# Altium schematic sheet Shift Registers.SchDoc
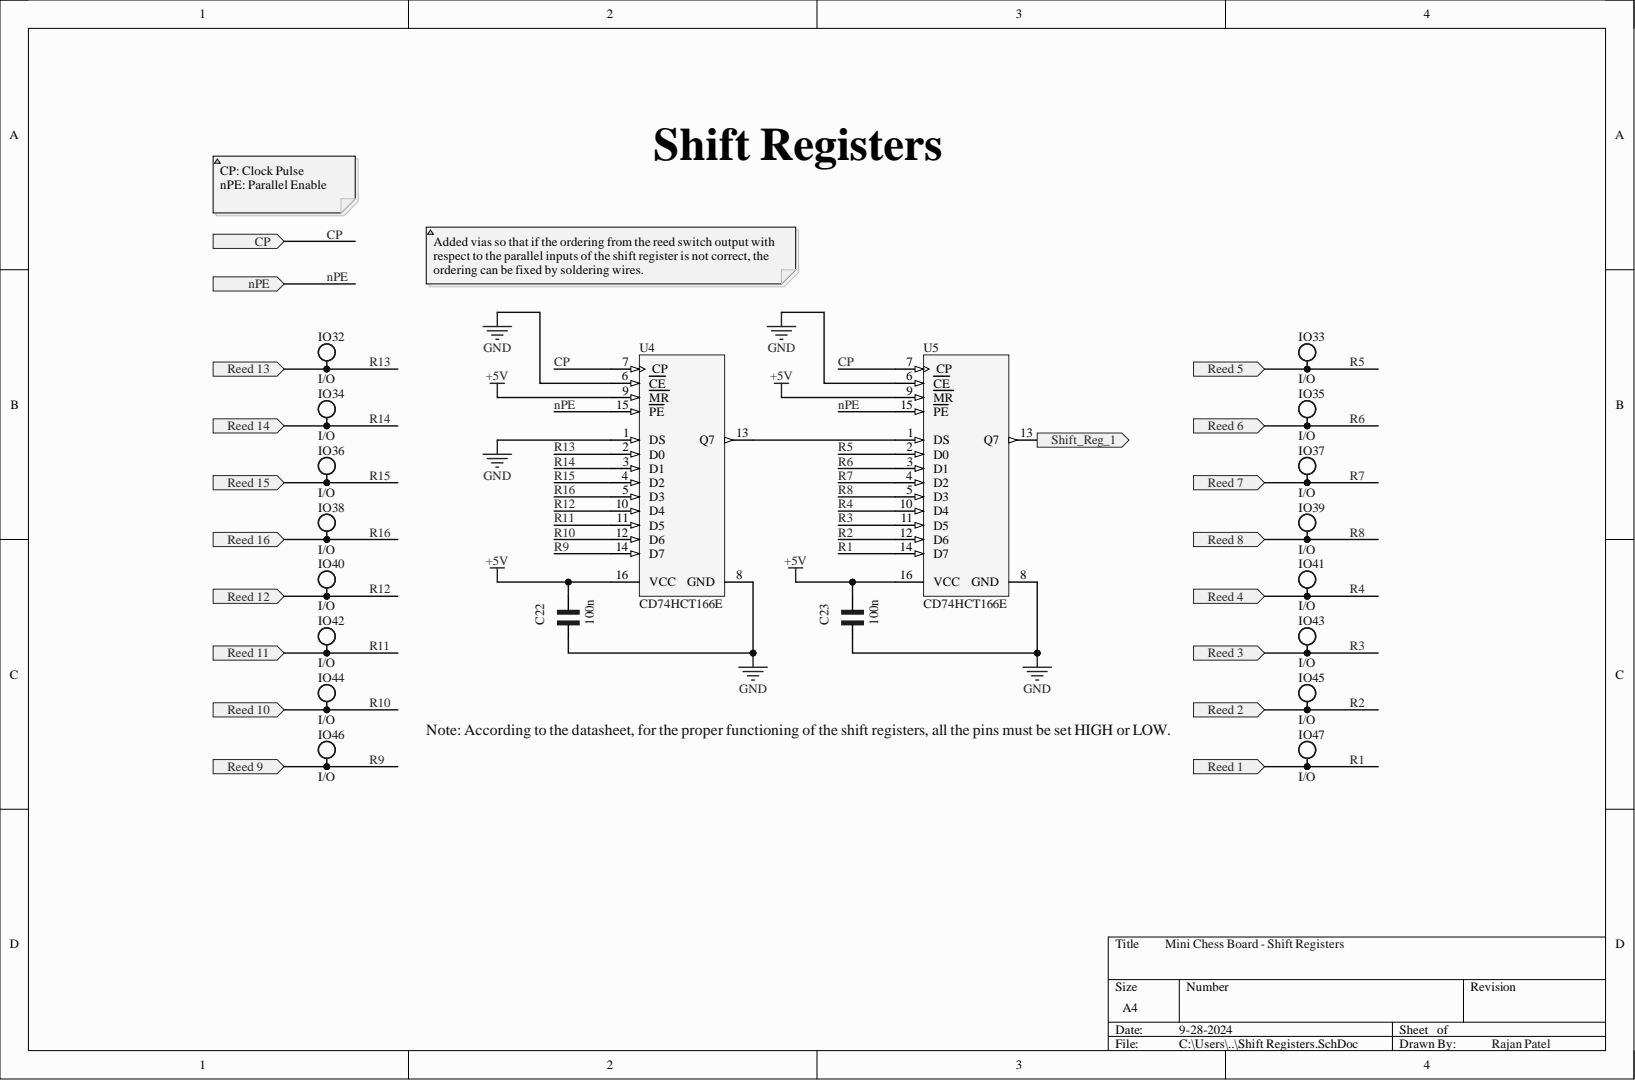
[diagram p0: Shift Registers.SchDoc]

## Parts
C22  100n  [CAP 0805]
C23  100n  [CAP 0805]
IO32  I/O  [I/O]
IO33  I/O  [I/O]
IO34  I/O  [I/O]
IO35  I/O  [I/O]
IO36  I/O  [I/O]
IO37  I/O  [I/O]
IO38  I/O  [I/O]
IO39  I/O  [I/O]
IO40  I/O  [I/O]
IO41  I/O  [I/O]
IO42  I/O  [I/O]
IO43  I/O  [I/O]
IO44  I/O  [I/O]
IO45  I/O  [I/O]
IO46  I/O  [I/O]
IO47  I/O  [I/O]
U4  CD74HCT166E  [N0016A]
U5  CD74HCT166E  [N0016A]

## Ports
CP, nPE, Reed 1, Reed 2, Reed 3, Reed 4, Reed 5, Reed 6, Reed 7, Reed 8, Reed 9, Reed 10, Reed 11, Reed 12, Reed 13, Reed 14, Reed 15, Reed 16, Shift_Reg_1

## Nets
+5V: C22.2 C23.2 U4.9(MR) U4.16(VCC) U5.9(MR) U5.16(VCC)
GND: C22.1 C23.1 U4.1(DS) U4.6(CE) U4.8(GND) U5.6(CE) U5.8(GND)
CP: U4.7(CP) U5.7(CP) ; port CP
nPE: U4.15(PE) U5.15(PE) ; port nPE
R1: IO47.1 U5.14(D7) ; port Reed 1
R2: IO45.1 U5.12(D6) ; port Reed 2
R3: IO43.1 U5.11(D5) ; port Reed 3
R4: IO41.1 U5.10(D4) ; port Reed 4
R5: IO33.1 U5.2(D0) ; port Reed 5
R6: IO35.1 U5.3(D1) ; port Reed 6
R7: IO37.1 U5.4(D2) ; port Reed 7
R8: IO39.1 U5.5(D3) ; port Reed 8
R9: IO46.1 U4.14(D7) ; port Reed 9
R10: IO44.1 U4.12(D6) ; port Reed 10
R11: IO42.1 U4.11(D5) ; port Reed 11
R12: IO40.1 U4.10(D4) ; port Reed 12
R13: IO32.1 U4.2(D0) ; port Reed 13
R14: IO34.1 U4.3(D1) ; port Reed 14
R15: IO36.1 U4.4(D2) ; port Reed 15
R16: IO38.1 U4.5(D3) ; port Reed 16
Shift_Reg_1: U5.13(Q7) ; port Shift_Reg_1
NetU4_13: U4.13(Q7) U5.1(DS)

## Library data of the parts
C22 C23 = CAP 0805: Check_prices: https://www.snapeda.com/parts/C2012X8R1H104K125AA/TDK/view-part/?ref=eda; Component Kind: Standard; SnapEDA_Link: https://www.snapeda.com/parts/C2012X8R1H104K125AA/TDK/view-part/?ref=snap; Library Name: MiniChessBoardSchLib.SchLib
U4 U5 = CMP-0807-00166-3: High Speed CMOS Logic 8-Bit Parallel-In/Serial-Out Shift Register, N0016A, TUBE; Manufacturer: Texas Instruments; 3-State Output: No; Bits: 8; F @ Nom Voltage(Max)(Mhz): 25; ICC @ Nom Voltage(Max)(mA): 0.08; Input Type: TTL; Output Drive (IOL/IOH)(Max)(mA): 4/-4; Rating: Catalog; Technology Family: HCT; tpd @ Nom Voltage(Max)(ns): 50; VCC(Max)(V): 5.5; VCC(Min)(V): 4.5; Voltage(Nom)(V): 5; Code_JEDEC: MS-001; Component Kind: Standard; DatasheetVersion: SCHS157C; Generic Part Number: CD74HCT166; Library Name: Altium Content Vault; Operating Temperature Range(C): -55 to 125; Output Type: CMOS; PackageReference: N0016A; PackageVersion: http://www.ti.com/lit/ml/mpdi002c/mpdi002c.pdf
IO32-IO47 = I/O: Component Kind: Standard; Library Name: MiniChessBoardSchLib.SchLib
